# Altium schematic sheet hw_tutorial.SchDoc(hw_tutorial)
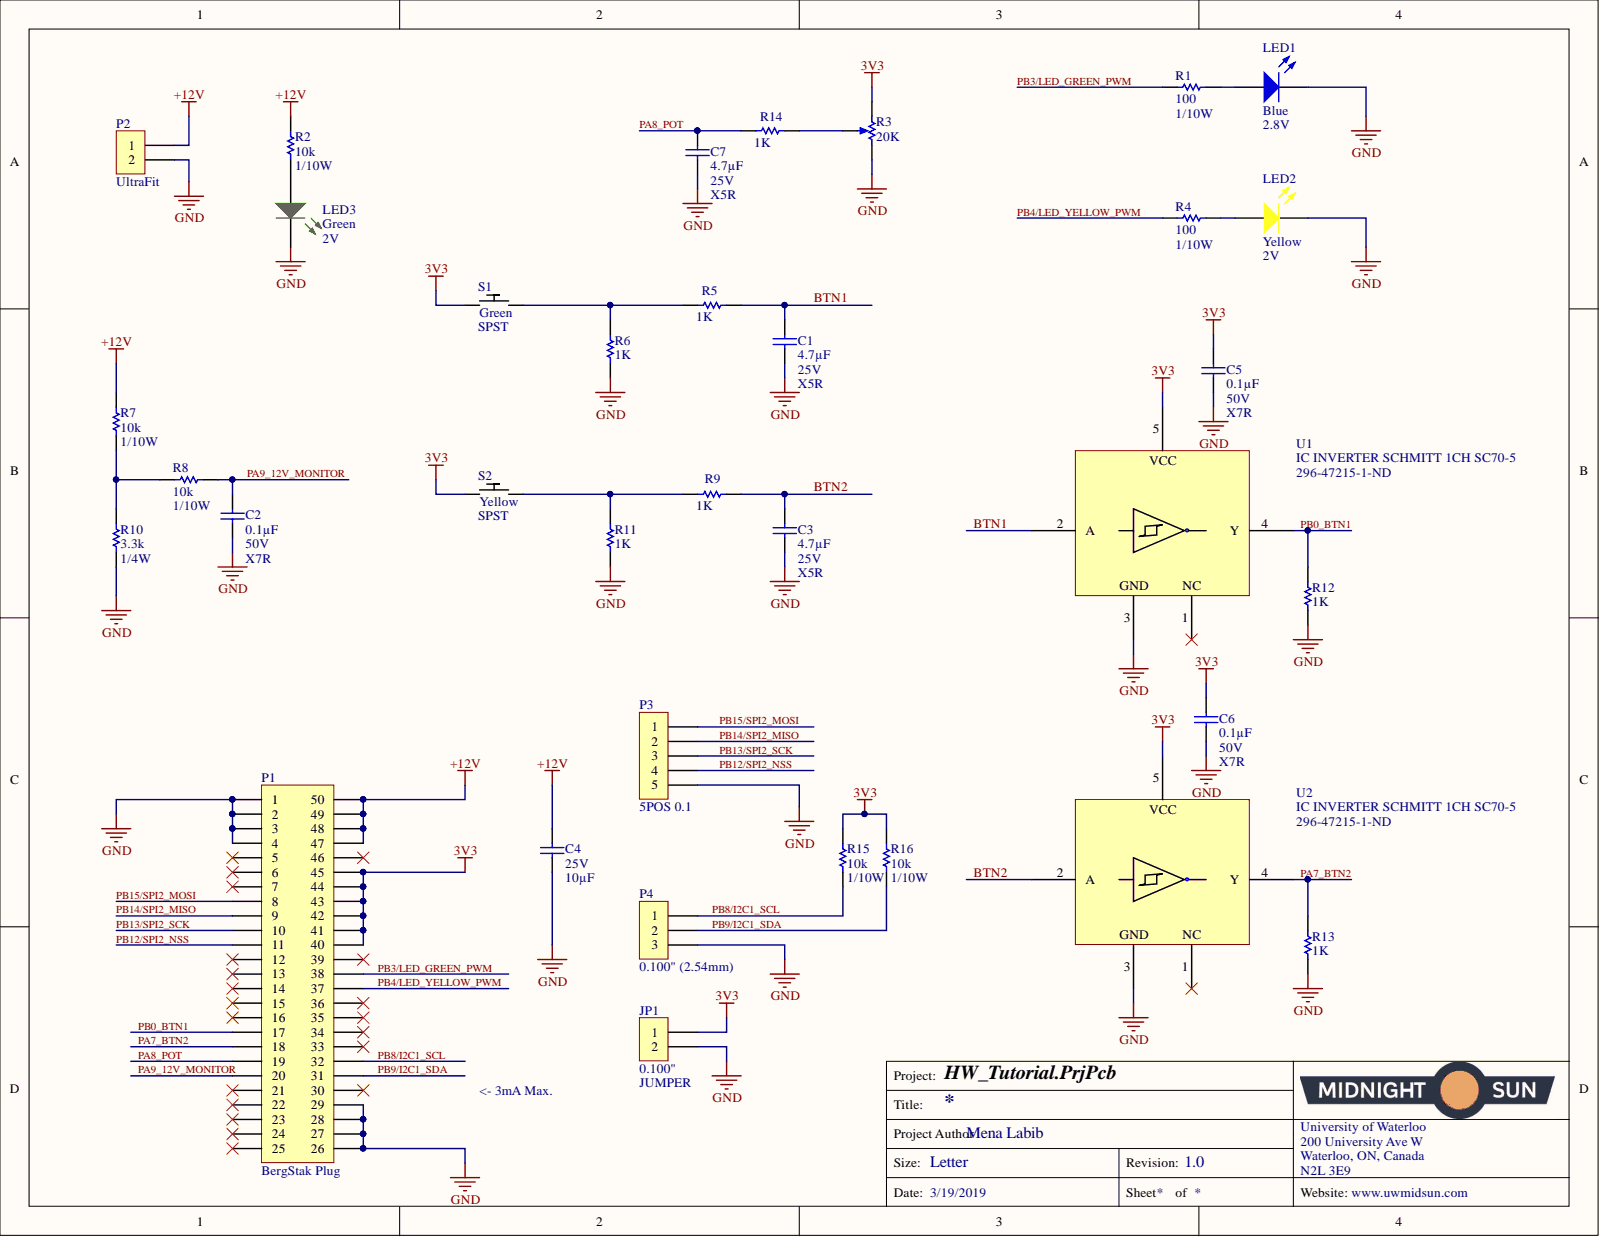
[diagram p0: hw_tutorial.SchDoc]

## Parts
C1  Voltage: 25V  Temperature Coefficient: X5R  Capacitance: 4.7µF  [CAP, 0603]  (hidden comment: CAP CER 4.7UF 25V 10% X5R 0603)
C2  Voltage: 50V  Temperature Coefficient: X7R  Capacitance: 0.1µF  [CAP, 0603]  (hidden comment: CAP CER 0.1UF 50V 10% X7R 0603)
C3  Voltage: 25V  Temperature Coefficient: X5R  Capacitance: 4.7µF  [CAP, 0603]  (hidden comment: CAP CER 4.7UF 25V 10% X5R 0603)
C4  Voltage - Rated: 25V  Capacitance: 10µF  [CAP, 0805]  (hidden comment: CAP CER 10uF 25V 10% X5R 0805)
C5  Voltage: 50V  Capacitance: 0.1µF  [CAP, 0603]  (hidden comment: CAP CER 0.1UF 50V 10% X7R 0603)
C6  Voltage: 50V  Capacitance: 0.1µF  [CAP, 0603]  (hidden comment: CAP CER 0.1UF 50V 10% X7R 0603)
C7  Voltage: 25V  Temperature Coefficient: X5R  Capacitance: 4.7µF  [CAP, 0603]  (hidden comment: CAP CER 4.7UF 25V 10% X5R 0603)
JP1  JUMPER  Row Spacing - Mating: 0.100"  [CONN, 2POS JUMPER 0.1"]
LED1  Voltage - Forward (Vf) (Typ): 2.8V  Color: Blue  [LED_0603]  (hidden comment: LED BLUE CLEAR 2.8V 0603)
LED2  Voltage - Forward (Vf) (Typ): 2V  Color: Yellow  [LED_0603]  (hidden comment: LED YELLOW CLEAR 2.1V 0603)
LED3  Voltage - Forward (Vf) (Typ): 2V  Color: Green  [LED_0603]  (hidden comment: LED GREEN CLEAR 2V 0603)
P1  Series: BergStak Plug  [CONN, Bergstak 50 Pos Plug]  (hidden comment: CONN 50POS Bergstak Plug 0.02")
P2  Series: UltraFit  [CONN, 2POS ULTRA-FIT]  (hidden comment: CONN 2POS ULTRA-FIT 0.138")
P3  5POS 0.1  [CONN, 5POS HEADR 0.1"]
P4  Pitch - Mating: 0.100" (2.54mm)  [CONN, 3POS 0.100" Header, 1 ROW]  (hidden comment: CONN 3POS HEADR MALE 0.1")
R1  Power: 1/10W  [RES, 0603]  (hidden comment: RES 100 OHM 1% 1/10W 0603)
R2  Resistance: 10k  Power: 1/10W  [RES, 0603]  (hidden comment: RES 10K OHM 1% 1/10W 0603)
R3  value 20K  [20K POT]  (hidden comment: POT 20k OHM 20% 1/4W)
R4  Power: 1/10W  [RES, 0603]  (hidden comment: RES 100 OHM 1% 1/10W 0603)
R5  1K  [RES, 0603]
R6  1K  [RES, 0603]
R7  Resistance: 10k  Power: 1/10W  [RES, 0603]  (hidden comment: RES 10K OHM 1% 1/10W 0603)
R8  Resistance: 10k  [RES, 0603]  (hidden comment: RES 10K OHM 1% 1/10W 0603)
R9  1K  [RES, 0603]
R10  Resistance: 3.3k  Power: 1/4W  [RES, 0603]  (hidden comment: RES 3.3K OHM 1% 1/4W 0603)
R11  1K  [RES, 0603]
R12  1K  [RES, 0603]
R13  1K  [RES, 0603]
R14  1K  [RES, 0603]
R15  Resistance: 10k  Power: 1/10W  [RES, 0603]  (hidden comment: RES 10K OHM 1% 1/10W 0603)
R16  Resistance: 10k  Power: 1/10W  [RES, 0603]  (hidden comment: RES 10K OHM 1% 1/10W 0603)
S1  SPST  Color - Actuator/Cap: Green  [SW SPST D6 SERIES GREEN]
S2  SPST  Color - Actuator/Cap: Yellow  [SW SPST D6 SERIES YELLOW]
U1  IC INVERTER SCHMITT 1CH SC70-5  Supplier Part Number 1: 296-47215-1-ND  [IC INVERTER SCHMITT 1CH SC70-5]
U2  IC INVERTER SCHMITT 1CH SC70-5  Supplier Part Number 1: 296-47215-1-ND  [IC INVERTER SCHMITT 1CH SC70-5]

## Nets
3V3: C5.1 C6.1 JP1.1 P1.40 P1.41 P1.42 P1.43 P1.44 P1.45 R3.1 R15.1 R16.1 S1.1 S2.1 U1.5 U2.5
+12V: C4.1 P1.47 P1.48 P1.49 P1.50 P2.1 R2.1 R7.1
GND: C1.2 C2.2 C3.2 C4.2 C5.2 C6.2 C7.2 JP1.2 LED1.2 LED2.2 LED3.2 P1.1 P1.2 P1.3 P1.4 P1.26 P1.27 P1.28 P1.29 P2.2 P3.5 P4.3 R3.3 R6.1 R10.2 R11.1 R12.1 R13.1 U1.3 U2.3
BTN1: C1.1 R5.2 U1.2(A)
BTN2: C3.1 R9.2 U2.2(A)
PA7_BTN2: P1.18 R13.2 U2.4(Y)
PA8_POT: C7.1 P1.19 R14.1
PA9_12V_MONITOR: C2.1 P1.20 R8.2
PB0_BTN1: P1.17 R12.2 U1.4(Y)
PB3/LED_GREEN_PWM: P1.38 R1.1
PB4/LED_YELLOW_PWM: P1.37 R4.1
PB8/I2C1_SCL: P1.32 P4.1 R15.2
PB9/I2C1_SDA: P1.31 P4.2 R16.2
PB12/SPI2_NSS: P1.11 P3.4
PB13/SPI2_SCK: P1.10 P3.3
PB14/SPI2_MISO: P1.9 P3.2
PB15/SPI2_MOSI: P1.8 P3.1
NetLED1_1: LED1.1 R1.2
NetLED2_1: LED2.1 R4.2
NetLED3_1: LED3.1 R2.2
NetR3_2: R3.2 R14.2
NetR5_1: R5.1 R6.2 S1.2
NetR7_2: R7.2 R8.1 R10.1
NetR9_1: R9.1 R11.2 S2.2

Not connected: P1 5 6 7 12 13 14 15 16 21 22 23 24 25 30 33 34 35 36 39 46; U1 1(NC); U2 1(NC)

## Library data of the parts
C1 C3 C7 = CAP CER 4.7UF 25V 10% X5R 0603: 4.7µF ±10% 25V Ceramic Capacitor X5R 0603 (1608 Metric); Manufacturer Part Number 1: GRM188R61E475KE11D; Manufacturer 1: Murata Electronics North America; Tolerance: ±10%; Thickness (Max): 0.031" (0.80mm); Supplier Part Number 1: 490-7203-1-ND; Supplier 1: Digi-Key; Size / Dimension: 0.063" L x 0.031" W (1.60mm x 0.80mm); Ratings: -; Part Status: Active; Packaging: Cut Tape (CT); Package / Case: 0603 (1608 Metric); Operating Temperature: -55°C ~ 85°C; Mounting Type: Surface Mount, MLCC; Lead Style: -; Lead Spacing: -; Height - Seated (Max): -; ComponentLink1URL: http://www.murata.com/~/media/webrenewal/support/library/catalog/products/capacitor/mlcc/c02e.ashx; ComponentLink1Description: http://www.murata.com/~/media/webrenewal/support/library/catalog/products/capacitor/mlcc/c02e.ashx; Component Kind: Standard; Library Name: Schematic Diagrams.SchLib
C2 C5 C6 = CAP CER 0.1UF 50V 10% X7R 0603: 0.10µF ±10% 50V Ceramic Capacitor X7R 0603 (1608 Metric); Manufacturer Part Number 1: 06035C104KAT2A; Manufacturer 1: AVX Corporation; Tolerance: ±10%; Thickness (Max): 0.035" (0.90mm); Supplier Part Number 1: 478-5052-1-ND; Supplier 1: Digi-Key; Size / Dimension: 0.063" L x 0.032" W (1.60mm x 0.81mm); Ratings: -; Part Status: Active; Packaging: Cut Tape (CT); Package / Case: 0603 (1608 Metric); Operating Temperature: -55°C ~ 125°C; Mounting Type: Surface Mount, MLCC; Lead Style: -; Lead Spacing: -; Height - Seated (Max): -; ComponentLink1URL: http://datasheets.avx.com/X7RDielectric.pdf; ComponentLink1Description: http://datasheets.avx.com/X7RDielectric.pdf; Component Kind: Standard; Library Name: Schematic Diagrams.SchLib
  C5 C6: Temperature Coefficient: X7R
C4 = CAP CER 10uF 25V 10% X5R 0805: 10µF ±10% 25V Ceramic Capacitor X5R 0805 (2012 Metric); Manufacturer Part Number: GRM21BR61E106KA73L; Manufacturer: Murata Electronics North America; Tolerance: ±10%; Thickness (Max): 0.053" (1.35mm); Temperature Coefficient: X5R; Supplier Part Number 1: 490-5523-1-ND; Supplier 1: Digi-Key; Stock 1: 1695157; Size / Dimension: 0.079" L x 0.049" W (2.00mm x 1.25mm); RoHS: RoHS Compliant; Ratings: -; Pricing 1: 1=0.3, 10=0.214, 100=0.1008, 500=0.07068, 1000=0.05851 (CAD); Part Status: Active; Packaging: Cut Tape (CT); Package / Case: 0805 (2012 Metric); Operating Temperature: -55°C ~ 85°C; Mounting Type: Surface Mount, MLCC; Lead Style: -; Lead Spacing: -; Height - Seated (Max): -; Features: -; ComponentLink1URL: http://search.murata.co.jp/Ceramy/image/img/A01X/G101/ENG/GRM21BR61E106KA73-01.pdf; ComponentLink1Description: http://search.murata.co.jp/Ceramy/image/img/A01X/G101/ENG/GRM21BR61E106KA73-01.pdf; Component Kind: Standard; Category: Capacitors; Applications: General Purpose; Library Name: Schematic Diagrams.SchLib
JP1 = CONN 2POS JUMPER 0.1": 2 Positions Header Connector Through Hole Gold; Manufacturer Part Number: XG8T-0231; Manufacturer: Omron Electronics Inc-EMC Div; Voltage Rating: 300V; Style: Board to Board or Cable; Shrouding: Unshrouded; Overall Contact Length: 0.386" (9.80mm); Number of Positions Loaded: All; Number of Positions: 2; Material Flammability Rating: UL94 V-0; Current Rating: 2A; Contact Length - Post: 0.118" (3.00mm); Contact Length - Mating: 0.169" (4.30mm); Type: JUMPER; Termination: Solder; Supplier Part Number 1: XG8T-0231-ND; Supplier 1: Digi-Key; Stock 1: 9094; RoHS: RoHS Compliant; Pricing 1: 1=0.28, 10=0.265, 100=0.183, 500=0.15484, 1000=0.12668, 5000=0.11261, 10000=0.10205 (CAD); Pitch - Mating: -; Part Status: Active; Packaging: Bulk; Operating Temperature: -55°C ~ 105°C; Number of Rows: 2; Mounting Type: Through Hole; Mated Stacking Heights: -; Insulation Material: Polybutylene Terephthalate (PBT), Glass Filled; Insulation Height: 0.098" (2.50mm); Insulation Color: Black; Ingress Protection: -; Features: -; Fastening Type: -; Contact Type: Male Pin; Contact Shape: Square; Contact Material: Brass, Nickel; Contact Finish Thickness - Post: -; Contact Finish Thickness - Mating: 5.9µin (0.15µm); Contact Finish - Post: Tin; Contact Finish - Mating: Gold; Connector Type: Header; ComponentLink1URL: http://www.omron.com/ecb/products/pdf/en-xj8.pdf; ComponentLink1Description: http://www.omron.com/ecb/products/pdf/en-xj8.pdf; Component Kind: Standard; Category: Connectors, Interconnects; Applications: -; Library Name: Schematic Diagrams.SchLib
LED1 = LED BLUE CLEAR 2.8V 0603: Blue 470nm LED Indication - Discrete 2.8V 0603 (1608 Metric); Manufacturer Part Number 1: LTST-C193TBKT-5A; Manufacturer 1: Lite-On Inc.; Wavelength - Peak: 468nm; Viewing Angle: 130°; Millicandela Rating: 15mcd; Lens Style/Size: Rectangle with Flat Top, 1.15mm x 0.80mm; Lens Color: Colorless; Current - Test: 5mA; Wavelength - Dominant: 470nm; Supplier Part Number 1: 160-1827-1-ND; Supplier Device Package: Chip LED; Supplier 1: Digi-Key; Size / Dimension: 1.60mm L x 0.80mm W; Part Status: Active; Packaging: Cut Tape (CT); Package / Case: 0603 (1608 Metric); Mounting Type: Surface Mount; Lens Transparency: Clear; Height (Max): 0.45mm; ComponentLink1URL: http://optoelectronics.liteon.com/upload/download/DS22-2004-060/P_100_LTST-C193TBKT-5A.pdf; ComponentLink1Description: http://optoelectronics.liteon.com/upload/download/DS22-2004-060/P_100_LTST-C193TBKT-5A.pdf; Component Kind: Standard; Library Name: Schematic Diagrams.SchLib
LED2 = LED YELLOW CLEAR 2.1V 0603: Yellow 590nm LED Indication - Discrete 2V 0603 (1608 Metric); Manufacturer Part Number: 150060YS75000; Manufacturer: Wurth Electronics Inc.; Wavelength - Peak: 595nm; Viewing Angle: 140°; Millicandela Rating: 120mcd; Lens Style/Size: Rectangle with Flat Top, 1.10mm x 0.70mm; Lens Color: Colorless; Current - Test: 20mA; Wavelength - Dominant: 590nm; Supplier Part Number 1: 732-4981-1-ND; Supplier Device Package: 0603; Supplier 1: Digi-Key; Stock 1: 10523; Size / Dimension: 1.60mm L x 0.80mm W; RoHS: RoHS Compliant; Pricing 1: 1=0.21, 100=0.1617, 500=0.13964 (CAD); Part Status: Active; Packaging: Cut Tape (CT); Package / Case: 0603 (1608 Metric); Mounting Type: Surface Mount; Lens Transparency: Clear; Height (Max): 0.80mm; Features: -; ComponentLink1URL: http://katalog.we-online.de/led/datasheet/150060YS75000.pdf; ComponentLink1Description: http://katalog.we-online.de/led/datasheet/150060YS75000.pdf; Component Kind: Standard; Category: Optoelectronics; Library Name: Schematic Diagrams.SchLib
LED3 = LED GREEN CLEAR 2V 0603: Green 572nm LED Indication - Discrete 2V 0603 (1608 Metric); Manufacturer Part Number: 150060VS75000; Manufacturer: Wurth Electronics Inc.; Wavelength - Dominant: 570nm; Viewing Angle: 140°; Millicandela Rating: 40mcd; Lens Style/Size: Rectangle with Flat Top, 1.10mm x 0.70mm; Lens Color: Colorless; Current - Test: 20mA; Wavelength - Peak: 572nm; Supplier Part Number 1: 732-4980-1-ND; Supplier Device Package: 0603; Supplier 1: Digi-Key; Stock 1: 9408; Size / Dimension: 1.60mm L x 0.80mm W; RoHS: RoHS Compliant; Pricing 1: 1=0.21, 100=0.1617, 500=0.13964 (CAD); Part Status: Active; Packaging: Cut Tape (CT); Package / Case: 0603 (1608 Metric); Mounting Type: Surface Mount; Lens Transparency: Clear; Height (Max): 0.80mm; Features: -; ComponentLink1URL: http://katalog.we-online.de/led/datasheet/150060VS75000.pdf; ComponentLink1Description: http://katalog.we-online.de/led/datasheet/150060VS75000.pdf; Component Kind: Standard; Category: Optoelectronics; Library Name: Schematic Diagrams.SchLib
P1 = CONN 50POS Bergstak Plug 0.02": 50 Position Connector Plug, Outer Shroud Contacts Surface Mount Gold; Manufacturer Part Number 1: 10132797-055100LF; Manufacturer 1: Amphenol FCI; Pitch: 0.020" (0.50mm); Number of Positions: 50; Mated Stacking Heights: 5mm; Supplier Part Number 1: 609-5226-1-ND; Supplier 1: Digi-Key; Part Status: Active; Packaging: Cut Tape (CT); Number of Rows: 2; Mounting Type: Surface Mount; Height Above Board: 0.169" (4.30mm); Contact Finish Thickness: 1µin (0.025µm); Contact Finish: Gold; Connector Type: Plug, Outer Shroud Contacts; ComponentLink1URL: http://portal.fciconnect.com/Comergent//fci/drawing/10132797.pdf; ComponentLink1Description: http://portal.fciconnect.com/Comergent//fci/drawing/10132797.pdf; Component Kind: Standard; Library Name: Schematic Diagrams.SchLib
P2 = CONN 2POS ULTRA-FIT 0.138": 2 Positions Header, Shrouded Connector 0.138" (3.50mm) Through Hole Gold; Manufacturer Part Number 1: 1722861302; Manufacturer 1: Molex, LLC; Voltage: 400V; Style: Board to Board or Cable; Shrouding: Shrouded - 4 Wall; Number of Positions Loaded: All; Number of Positions: 2; Material Flammability Rating: UL94 V-0; Mated Stacking Heights: 26.99mm; Contact Length - Post: 0.126" (3.20mm); Termination: Kinked Pin, Solder; Supplier Part Number 1: WM11673-ND; Supplier 1: Digi-Key; Row Spacing - Mating: -; Pitch - Mating: 0.138" (3.50mm); Part Status: Active; Packaging: Tray; Overall Contact Length: -; Operating Temperature: -40°C ~ 120°C; Number of Rows: 1; Mounting Type: Through Hole; Insulation Material: Liquid Crystal Polymer (LCP); Insulation Height: 0.465" (11.80mm); Insulation Color: Black; Ingress Protection: -; Fastening Type: Latch Holder; Current Rating: -; Contact Type: Male Pin; Contact Shape: Square; Contact Material: Copper Alloy; Contact Length - Mating: -; Contact Finish Thickness - Post: 60µin (1.52µm); Contact Finish Thickness - Mating: 30µin (0.76µm); Contact Finish - Post: Tin; Contact Finish - Mating: Gold; Connector Type: Header; ComponentLink1URL: http://www.molex.com/pdm_docs/sd/1722861302_sd.pdf; ComponentLink1Description: http://www.molex.com/pdm_docs/sd/1722861302_sd.pdf; Component Kind: Standard; Library Name: Schematic Diagrams.SchLib
P3 = CONN 5POS HEADR MALE 0.1in: 5 Positions Header, Breakaway Connector 0.100" (2.54mm) Through Hole Tin; Manufacturer Part Number 1: 0022284050; Manufacturer 1: Molex; Number of Positions: 5; Max Voltage Rating (DC): 250V; Gender: Male; Description 1: Conn Unshrouded Header HDR 5 POS 2.54mm Solder ST Thru-Hole KK® Bag; Contact Resistance: 20mR; Connector Type: Male Pin; Termination: Solder; Supplier Part Number 1: WM50014-05-ND; Supplier 1: Digi-Key; Stock 1: 3161; Pricing 1: 1=0.22, 10=0.21, 25=0.1796, 50=0.157, 100=0.1459, 250=0.129, 500=0.1234 (USD); Pitch: 2.54mm; Packaging: Bulk; Orientation: Straight; Number of Rows: 1; Number of Contacts: 5; Mount: Through Hole; Min Operating Temperature: 0°C; Max Voltage Rating (AC): 250V; Max Operating Temperature: 75°C; Length: 12.7mm; Housing Material: Nylon; Housing Colour: Black; Depth: 2.49mm; Contact Plating: Nickel,Tin; Contact Mating Length: 0.24inch; Contact Material: Bronze,Brass; ComponentLink3URL: https://datasheet.ciiva.com/15217/getdatasheetpartid-256138-15217709.pdf; ComponentLink3Description: https://datasheet.ciiva.com/15217/getdatasheetpartid-256138-15217709.pdf; ComponentLink2URL: https://datasheet.ciiva.com/14804/179390022284050-pcb-headers-14804361.pdf; ComponentLink2Description: https://datasheet.ciiva.com/14804/179390022284050-pcb-headers-14804361.pdf; ComponentLink1URL: https://datasheet.ciiva.com/6814/022284363-sd-6814049.pdf; ComponentLink1Description: https://datasheet.ciiva.com/6814/022284363-sd-6814049.pdf; Component Kind: Standard; Colour: Black; Library Name: Schematic Diagrams.SchLib
P4 = CONN 3POS HEADR MALE 0.1": 3 Positions Header Connector 0.100" (2.54mm) Through Hole Gold; Manufacturer Part Number 1: 61300311121; Manufacturer 1: Wurth Electronics Inc.; Voltage: 250V; Style: Board to Board or Cable; Shrouding: Unshrouded; Overall Contact Length: 0.454" (11.54mm); Number of Positions Loaded: All; Number of Positions: 3; Material Flammability Rating: UL94 V-0; Current Rating: 3A; Contact Length - Post: 0.118" (3.00mm); Contact Length - Mating: 0.236" (6.00mm); Termination: Solder; Supplier Part Number 1: 732-5316-ND; Supplier 1: Digi-Key; Row Spacing - Mating: -; Part Status: Active; Packaging: Bulk; Operating Temperature: -40°C ~ 125°C; Number of Rows: 1; Mounting Type: Through Hole; Mated Stacking Heights: -; Insulation Material: Polybutylene Terephthalate (PBT); Insulation Height: 0.100" (2.54mm); Insulation Color: Black; Ingress Protection: -; Fastening Type: -; Contact Type: Male Pin; Contact Shape: Square; Contact Material: Copper Alloy; Contact Finish Thickness - Post: -; Contact Finish Thickness - Mating: -; Contact Finish - Post: -; Contact Finish - Mating: Gold; Connector Type: Header; ComponentLink1URL: http://katalog.we-online.de/em/datasheet/6130xx11121.pdf; ComponentLink1Description: http://katalog.we-online.de/em/datasheet/6130xx11121.pdf; Component Kind: Standard; Library Name: Schematic Diagrams.SchLib
R1 R4 = RES 100 OHM 1% 1/10W 0603: 100 Ohm ±1% 0.1W, 1/10W Chip Resistor 0603 (1608 Metric) Moisture Resistant Thick Film; Manufacturer Part Number 1: RC0603FR-07100RL; Manufacturer 1: Yageo; Temperature Coefficient: ±100ppm/°C; Resistance: 100; Tolerance: ±1%; Supplier Part Number 1: 311-100HRCT-ND; Supplier Device Package: 0603; Supplier 1: Digi-Key; Size / Dimension: 0.063" L x 0.031" W (1.60mm x 0.80mm); Part Status: Active; Packaging: Cut Tape (CT); Package / Case: 0603 (1608 Metric); Operating Temperature: -55°C ~ 155°C; Height - Seated (Max): 0.022" (0.55mm); Failure Rate: -; Composition: Thick Film; ComponentLink1URL: http://www.yageo.com/documents/recent/PYu-RC_Group_51_RoHS_L_7.pdf; ComponentLink1Description: http://www.yageo.com/documents/recent/PYu-RC_Group_51_RoHS_L_7.pdf; Component Kind: Standard; Library Name: Schematic Diagrams.SchLib
R2 R7 R8 R15 R16 = RES 10K OHM 1% 1/10W 0603: RES SMD 10K OHM 1% 1/10W 0603; Manufacturer Part Number 1: RC0603FR-0710KL; Manufacturer 1: Yageo; Temperature Coefficient: ±100ppm/°C; Tolerance: ±1%; Supplier Part Number 1: 311-10.0KHRCT-ND; Supplier Device Package: 0603; Supplier 1: Digi-Key; Size / Dimension: 0.063" L x 0.031" W (1.60mm x 0.80mm); Part Status: Active; Packaging: Cut Tape (CT); Package / Case: 0603 (1608 Metric); Operating Temperature: -55°C ~ 155°C; Height - Seated (Max): 0.022" (0.55mm); Failure Rate: -; Composition: Thick Film; ComponentLink1URL: http://www.yageo.com/documents/recent/PYu-RC_Group_51_RoHS_L_7.pdf; ComponentLink1Description: http://www.yageo.com/documents/recent/PYu-RC_Group_51_RoHS_L_7.pdf; Component Kind: Standard; Library Name: Schematic Diagrams.SchLib
  R8: Power: 1/10W
R3 = POT 20k OHM 20% 1/4W: 20k Ohm 0.25W, 1/4W Gull Wing Surface Mount Trimmer Potentiometer; Manufacture Part Number: 23BR20KLFTR; Manufacture: TT Electronics/BI; Turns: 1; Adjustment Type: Top; Tolerence: 20%; Supplier Part Number 1: 987-1016-1-ND; Supplier 1: Digi-Key; Simulation: POT; Power: 1/4W; Component Kind: Standard; Library Name: Schematic Diagrams.SchLib
R5 R6 R9 R11-R14 = RES 1K OHM 5% 1/10W 0603: 1k Ohm ±5% 0.1W, 1/10W Chip Resistor 0603 (1608 Metric); Manufacturer Part Number: RC0603JR-071KL; Manufacturer: Yageo; Temperature Coefficient: ±100ppm/°C; Tolerance: ±5%; Supplier Part Number 1: 311-1.0KGRCT-ND; Supplier Device Package: 0603; Supplier 1: Digi-Key; Stock 1: 4415240; Size / Dimension: 0.063" L x 0.031" W (1.60mm x 0.80mm); RoHS: RoHS Compliant; Resistance (Ohms): 1k; Pricing 1: 1=0.15, 10=0.017, 100=0.0071, 1000=0.0032, 2500=0.00278 (CAD); Power (Watts): 0.1W, 1/10W; Part Status: Active; Packaging: Cut Tape (CT); Package / Case: 0603 (1608 Metric); Operating Temperature: -55°C ~ 155°C; Height - Seated (Max): 0.022" (0.55mm); Features: Moisture Resistant; Failure Rate: -; Composition: Thick Film; ComponentLink1URL: http://www.yageo.com/documents/recent/PYu-RC_Group_51_RoHS_L_7.pdf; ComponentLink1Description: http://www.yageo.com/documents/recent/PYu-RC_Group_51_RoHS_L_7.pdf; Component Kind: Standard; Category: Resistors; Library Name: Schematic Diagrams.SchLib
R10 = RES 3.3K OHM 1% 1/4W 0603: 3.3k Ohm ±1% 0.25W, 1/4W Chip Resistor 0603 (1608 Metric) Automotive AEC-Q200, Pulse Withstanding Thick Film; Manufacturer Part Number 1: ERJ-PA3F3301V; Manufacturer 1: Panasonic Electronic Components; Temperature Coefficient: ±100ppm/°C; Tolerance: ±1%; Supplier Part Number 1: P3.3KBYCT-ND; Supplier Device Package: 0603; Supplier 1: Digi-Key; Size / Dimension: 0.063" L x 0.031" W (1.60mm x 0.80mm); Part Status: Active; Packaging: Cut Tape (CT); Package / Case: 0603 (1608 Metric); Operating Temperature: -55°C ~ 155°C; Height - Seated (Max): 0.022" (0.55mm); Failure Rate: -; Composition: Thick Film; ComponentLink1URL: https://industrial.panasonic.com/cdbs/www-data/pdf/RDO0000/AOA0000C291.pdf; ComponentLink1Description: https://industrial.panasonic.com/cdbs/www-data/pdf/RDO0000/AOA0000C291.pdf; Component Kind: Standard; Library Name: Schematic Diagrams.SchLib
S1 = SW SPST-NO 0.1A 32V D6 Series Green: Pushbutton Switch SPST-NO Keyswitch Through Hole D6 Series Green; Manufacturer Part Number: D6R50 F1 LFS; Manufacturer: C&K; Voltage Rating - DC: 32V; Switch Function: Off-Mom; Electrical Life: 250,000 Cycles; Current Rating: 100mA (DC); Actuator Type: Round, Button; Voltage Rating - AC: -; Type: Keyswitch; Termination Style: PC Pin; Supplier Part Number 1: 401-1976-ND; Supplier 1: Digi-Key; Stock 1: 3742; RoHS: RoHS Compliant; Pricing 1: 1=1.73, 10=1.607, 25=1.5308, 50=1.454, 100=1.4158, 250=1.37756, 500=1.30102, 1000=1.22448, 5000=1.03316 (CAD); Part Status: Active; Panel Cutout Dimensions: -; Packaging: Bulk; Operating Temperature: -20°C ~ 85°C; Mounting Type: Through Hole; Mechanical Life: -; Ingress Protection: -; Illumination Voltage (Nominal): -; Illumination Type, Color: -; Features: Tactile Feedback; ComponentLink1URL: http://www.ckswitches.com/media/1341/d6.pdf; ComponentLink1Description: http://www.ckswitches.com/media/1341/d6.pdf; Component Kind: Standard; Circuit: SPST-NO; Category: Switches; Library Name: Schematic Diagrams.SchLib
S2 = SW SPST-NO 0.1A 32V D6 Series Yellow: Pushbutton Switch SPST-NO Keyswitch Through Hole D6 Series Yellow; Manufacturer Part Number: D6R30 F1 LFS; Manufacturer: C&K; Voltage Rating - DC: 32V; Switch Function: Off-Mom; Electrical Life: 250,000 Cycles; Current Rating: 100mA (DC); Actuator Type: Round, Button; Voltage Rating - AC: -; Type: Keyswitch; Termination Style: PC Pin; Supplier Part Number 1: 401-1974-ND; Supplier 1: Digi-Key; Stock 1: 2814; RoHS: RoHS Compliant; Pricing 1: 1=1.73, 10=1.607, 25=1.5308, 50=1.454, 100=1.4158, 250=1.37756, 500=1.30102, 1000=1.22448, 5000=1.03316 (CAD); Part Status: Active; Panel Cutout Dimensions: -; Packaging: Bulk; Operating Temperature: -20°C ~ 85°C; Mounting Type: Through Hole; Mechanical Life: -; Ingress Protection: -; Illumination Voltage (Nominal): -; Illumination Type, Color: -; Features: Tactile Feedback; ComponentLink1URL: http://www.ckswitches.com/media/1341/d6.pdf; ComponentLink1Description: http://www.ckswitches.com/media/1341/d6.pdf; Component Kind: Standard; Circuit: SPST-NO; Category: Switches; Library Name: Schematic Diagrams.SchLib
U1 U2 = IC INVERTER SCHMITT 1CH SC70-5: Inverter IC 1 Channel Schmitt Trigger SC-70-5; Manufacturer Part Number: M74HC14YTTR; Manufacturer: STMicroelectronics; Turn-On Delay Time: 25ns; Quiescent Current: 1uA; Propagation Delay: 21ns; Number of Inputs: 6; Number of Bits: 1; Min Supply Voltage: 2V; Max Supply Voltage: 6V; Low Level Output Current: 5.2mA; Weight: 0.004949oz; Supplier 1: Digi-Key; Stock 1: 11031; RoHS: Yes; Pricing 1: 1 = 0.36000, 10 = 0.29000, 100 = 0.19780, 500 = 0.14832, 1000 = 0.11124 (USD); Packaging: Tape and Reel; Package Quantity: 2500; Number of Pins: 14; Number of Circuits: 6; Mount: Surface Mount; Min Operating Temperature: -40°C; Max Operating Temperature: 125°C; Logic Function: Inverter; Lead Free: Lead Free; High Level Output Current: 5.2mA; Component Kind: Standard; Case/Package: TSSOP; Library Name: Schematic Diagrams.SchLib
